# Altium schematic sheet MCU.SchDoc
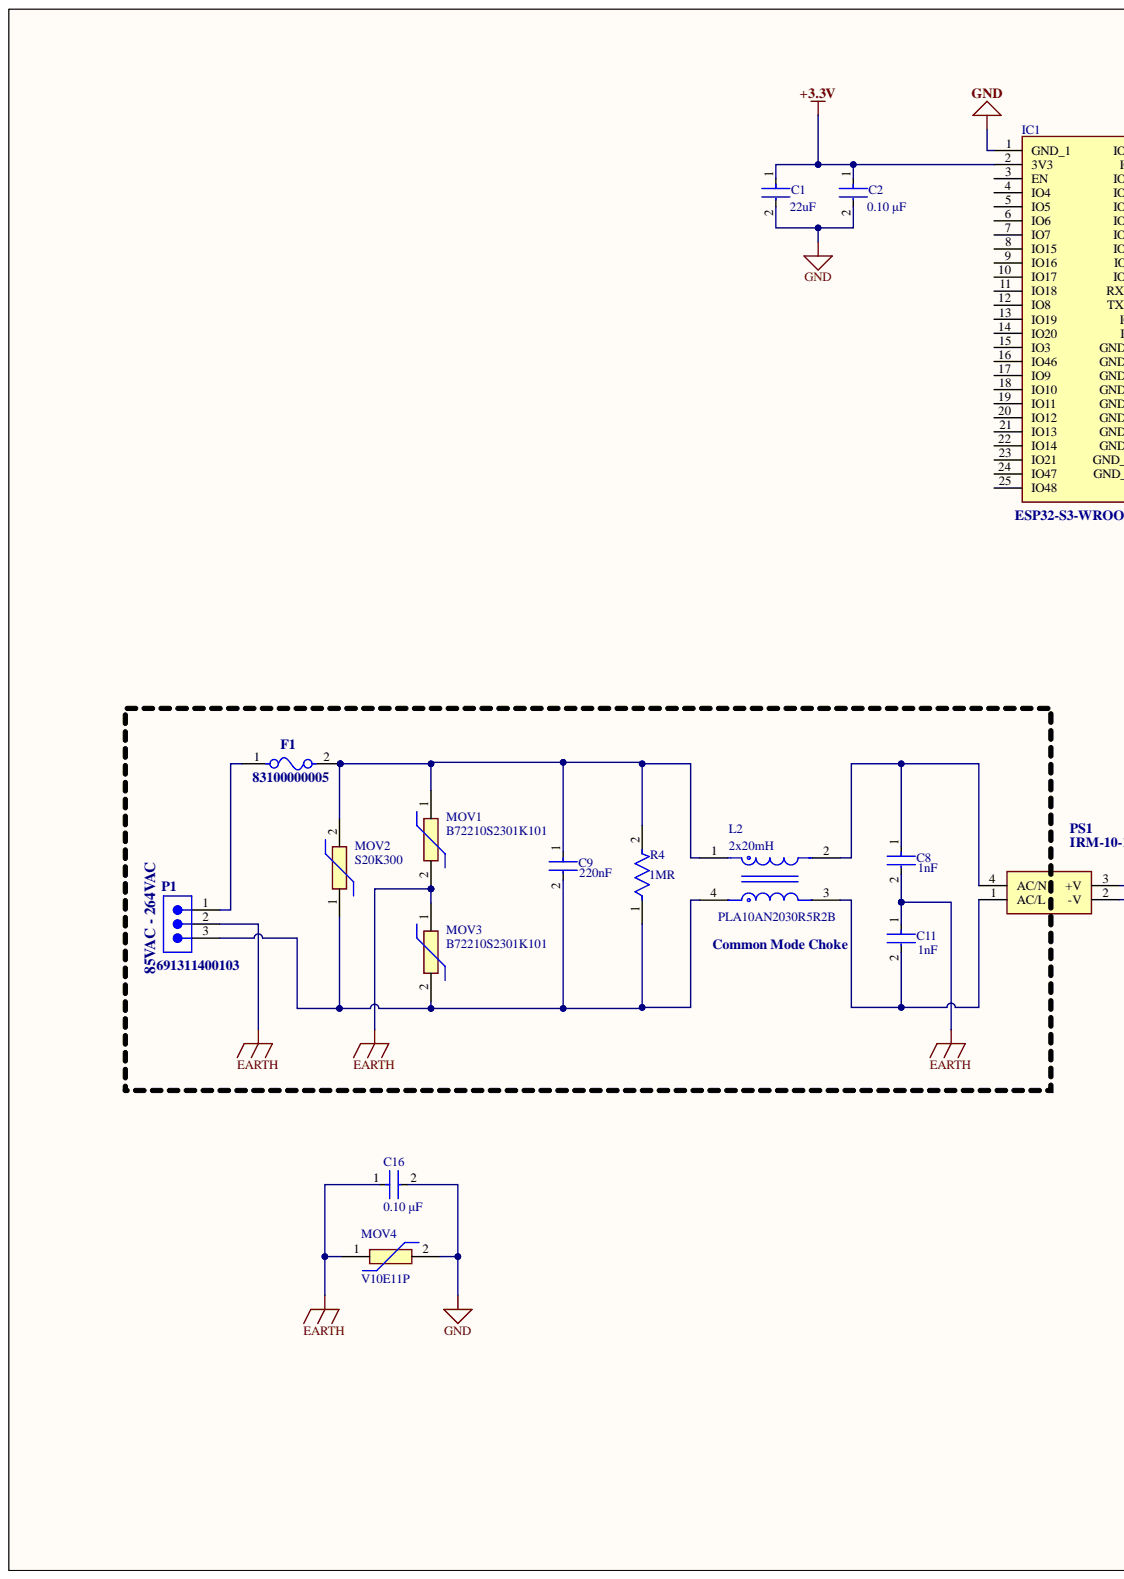
[diagram p0: MCU.SchDoc, left]
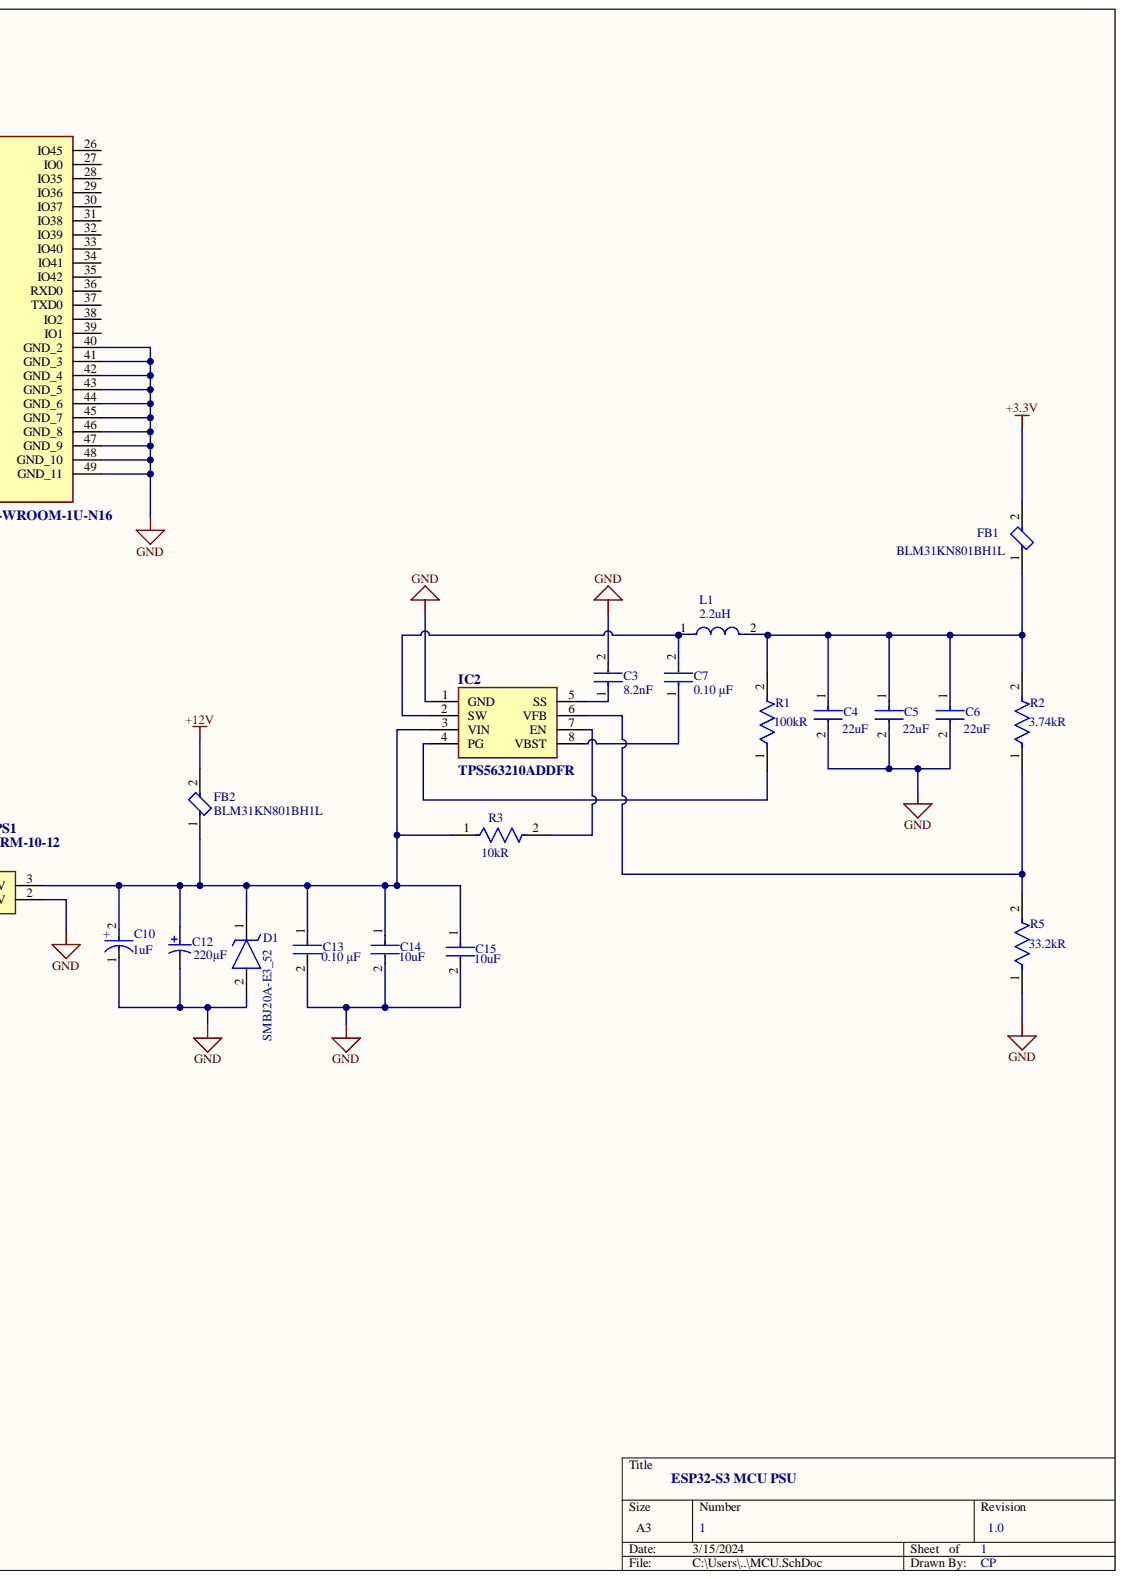
[diagram p1: MCU.SchDoc, right]

## Parts
C1  Capacitance: 22uF  [FP-C4532-250-0_4-IPC_B]  (hidden comment: C4532X7R1E226M250KC)
C2  value 0.10 μF  Capacitance: 0.10 μF  [FP-C1206C-EB-MFG]  (hidden comment: C1206C104K2RACTU)
C3  Capacitance: 8.2nF  [FP-CGA5L-0_3-0_1-IPC_A]  (hidden comment: CGA5H4C0G2W822J115AA)
C4  Capacitance: 22uF  [FP-C4532-250-0_4-IPC_B]  (hidden comment: C4532X7R1E226M250KC)
C5  Capacitance: 22uF  [FP-C4532-250-0_4-IPC_B]  (hidden comment: C4532X7R1E226M250KC)
C6  Capacitance: 22uF  [FP-C4532-250-0_4-IPC_B]  (hidden comment: C4532X7R1E226M250KC)
C7  value 0.10 μF  Capacitance: 0.10 μF  [FP-C1206C-EB-MFG]  (hidden comment: C1206C104K2RACTU)
C8  Capacitance: 1nF  [FP-VY1102M31Y5UC63V0-MFG]  (hidden comment: VY1102M31Y5UC63V0)
C9  Capacitance: 220nF  [FP-C5750-230-0_4-IPC_C]  (hidden comment: C5750JB2J224K230KA)
C10  Capacitance: 1uF  [FP-T491D476K025AT-MFG]  (hidden comment: T491D476K025AT)
C11  Capacitance: 1nF  [FP-VY1102M31Y5UC63V0-MFG]  (hidden comment: VY1102M31Y5UC63V0)
C12  Capacitance: 220µF  [PNSC-FK-V-H13_V]  (hidden comment: EEVFK1J221Q)
C13  value 0.10 μF  Capacitance: 0.10 μF  [FP-C1206C-EB-MFG]  (hidden comment: C1206C104K2RACTU)
C14  value 10uF  Capacitance: 10uF  [FP-GRM31-0_2-MFG]  (hidden comment: GRM31CR61H106KA12L)
C15  value 10uF  Capacitance: 10uF  [FP-GRM31-0_2-MFG]  (hidden comment: GRM31CR61H106KA12L)
C16  value 0.10 μF  Capacitance: 0.10 μF  [FP-C1206C-EB-MFG]  (hidden comment: C1206C104K2RACTU)
D1  SMBJ20A-E3_52  [DIOM5436X244N]
F1  83100000005  [FP-831-MFG]
FB1  BLM31KN801BH1L  [FP-BLM31-0_2-t1_6-MFG]
FB2  BLM31KN801BH1L  [FP-BLM31-0_2-t1_6-MFG]
IC1  ESP32-S3-WROOM-1U-N16  [ESP32S3WROOM1UN16]
IC2  TPS563210ADDFR  [SOT65P280X110-8N]
L1  Inductance: 2.2uH  [FP-LQM31PN_00-MFG]  (hidden comment: LQM31PN2R2M00L)
L2  PLA10AN2030R5R2B  Parameter 1: 2x20mH  [FP-PLA10A-PLH10A-MFG]
MOV1  B72210S2301K101  [B72210S2301K101]
MOV2  S20K300  [S20K300]
MOV3  B72210S2301K101  [B72210S2301K101]
MOV4  V10E11P  [V10E11P]
P1  691311400103  [691311400103]
PS1  IRM-10-12  [IRM-10]
R1  Resistance: 100kR  [FP-CRCW1206-e3-IPC_C]  (hidden comment: CRCW1206100KJNEB)
R2  Resistance: 3.74kR  [FP-MCA1206-IPC_A]  (hidden comment: MCA1206MD1002BP100)
R3  Resistance: 10kR  [FP-MCA1206-IPC_A]  (hidden comment: MCA1206MD1002BP100)
R4  Resistance: 1MR  [FP-CRCW1206-e3-IPC_C]  (hidden comment: CRCW1206100KJNEB)
R5  Resistance: 33.2kR  [FP-MCA1206-IPC_A]  (hidden comment: MCA1206MD1002BP100)

## Nets
+3.3V: C1.1 C2.1 FB1.2 IC1.2(3V3)
+12V: FB2.2
EARTH: C8.2 C11.1 C16.1 MOV1.2 MOV3.1 MOV4.1 P1.2
GND: C1.2 C2.2 C3.2 C4.2 C5.2 C6.2 C10.1 C12.2 C13.2 C14.2 C15.2 C16.2 D1.2 IC1.1(GND_1) IC1.40(GND_2) IC1.41(GND_3) IC1.42(GND_4) IC1.43(GND_5) IC1.44(GND_6) IC1.45(GND_7) IC1.46(GND_8) IC1.47(GND_9) IC1.48(GND_10) IC1.49(GND_11) IC2.1(GND) MOV4.2 PS1.2(-V) R5.1
NetC3_1: C3.1 IC2.5(SS)
NetC4_1: C4.1 C5.1 C6.1 FB1.1 L1.2 R1.2 R2.2
NetC7_1: C7.1 IC2.8(VBST)
NetC7_2: C7.2 IC2.2(SW) L1.1
NetC8_1: C8.1 L2.2 PS1.4(AC/N)
NetC9_1: C9.1 F1.2 L2.1 MOV1.1 MOV2.2 R4.2
NetC9_2: C9.2 L2.4 MOV2.1 MOV3.2 P1.3 R4.1
NetC10_2: C10.2 C12.1 C13.1 C14.1 C15.1 D1.1 FB2.1 IC2.3(VIN) PS1.3(+V) R3.1
NetC11_2: C11.2 L2.3 PS1.1(AC/L)
NetF1_1: F1.1 P1.1
NetIC2_4: IC2.4(PG) R1.1
NetIC2_6: IC2.6(VFB) R2.1 R5.2
NetIC2_7: IC2.7(EN) R3.2

Not connected: IC1 3(EN) 4(IO4) 5(IO5) 6(IO6) 7(IO7) 8(IO15) 9(IO16) 10(IO17) 11(IO18) 12(IO8) 13(IO19) 14(IO20) 15(IO3) 16(IO46) 17(IO9) 18(IO10) 19(IO11) 20(IO12) 21(IO13) 22(IO14) 23(IO21) 24(IO47) 25(IO48) 26(IO45) 27(IO0) 28(IO35) 29(IO36) 30(IO37) 31(IO38) 32(IO39) 33(IO40) 34(IO41) 35(IO42) 36(RXD0) 37(TXD0) 38(IO2) 39(IO1)

## Library data of the parts
C1 C4-C6 = CMP-08246-003643-1: Multilayer Ceramic Capacitors 22uF ±20% 25V X7R SMD 1812; Case Code (Imperial): 1812; Case Code (Metric): 4532; Case/Package: 1812; Component Kind: Standard; Construction: Flat; Depth: 3.2mm; Dielectric: X7R; Dielectric Material: Ceramic; Height: 2.5mm; Lead Free: Lead Free; Length: 4.5mm; Library Name: Altium Content Vault; Max Operating Temperature: 125°C; Min Operating Temperature: -55°C; Mount: Surface Mount; Number of Pins: 2; Package Quantity: 1; Packaging: Tape and Reel; Radiation Hardening: No; REACH SVHC: No SVHC; Resistor Type: Ceramic; RoHS Compliant: Yes; Series: C; Termination: SMD/SMT; Thickness: 0.11inch; Tolerance: 20%; Voltage: 25V; Voltage Rating: 25V; Voltage Rating (DC): 25V; Weight: 0.000952oz; Width: 0.126inch
C2 C7 C13 C16 = CMP-1037-04222-2: CAP CER 0.1UF 200V 10% X7R 1206; Manufacturer: KEMET; Automotive Grade: Grade 0; Material: Ceramic; Temperature Coefficient: 15%; Tolerance: 0.1; Automotive: Yes; Category: Cap; Component Kind: Standard; ComponentLink2Description: Datasheet; ComponentLink2URL: https://content.kemet.com/datasheets/KEM_C1007_X8R_ULTRA_150C_SMD.pdf; Device Class L1: Passive Components; Device Class L2: Capacitors; Device Class L3: Ceramic Capacitors; Digikey Description: CAP CER 0.1UF 200V 10% X7R 1206; Height: 0.88mm; Ipc Land Pattern Name: CAPC320160X78; Lead Free: Yes; Library Name: Altium Content Vault; Manufacturer Part Number: C1206C104K2RACTU; Mouser Description: Multilayer Ceramic Capacitors MLCC - SMD/SMT 200volts 0.1uF X7R 10%; Octopart: https://octopart.com/c1206c104k2ractu-kemet-9138344; Package: C1206C-EB; RoHS: TRUE; Temperature: -55°C to +150°C; Temperature Characteristic: X7R; Temperature Range High: +150°C; Temperature Range Low: -55°C; Voltage Rating: 200 V
C3 = CMP-08246-000279-1: Cap Ceramic 8.2nF 450V C0G 5% Pad SMD 1206 +125°C Automotive T/R; Case Code (Imperial): 1206; Case Code (Metric): 3216; Case/Package: 1206; Component Kind: Standard; Composition: Ceramic; Dielectric: C0G; Height: 1.15mm; Length: 0.126inch; Library Name: Altium Content Vault; Max Operating Temperature: 125°C; Min Operating Temperature: -55°C; Mount: Surface Mount; Package Quantity: 2000; Packaging: Tape and Reel; Ratings: AEC-Q200; Termination: SMD/SMT; Thickness: 0.051inch; Tolerance: 5%; Voltage Rating: 450V; Voltage Rating (DC): 450V; Width: 0.063inch
C8 C11 = CMP-00030-59531954-1: VY1102M31Y5UC63V0 1nF 500(Y1)V ac; 760(X1)V ac Ceramic Single Layer Cap; Y5U Dielectric VY1 Series; Dissipation Factor: 2.5%; Lead/Base Style: Kinked; Ratings: X1, Y1; Tolerance: 20%; Case/Package: Radial; Component Kind: Standard; Depth: 5mm; Diameter: 8mm; Dielectric: Y5U; Dielectric Material: Ceramic; Height - Seated (Max): 11.9888mm; Lead Pitch: 10.0076mm; Library Name: Altium Content Vault; Max Operating Temperature: 125°C; Min Operating Temperature: -40°C; Mount: Through Hole; NexarPartId: 59531954; Packaging: Bulk; REACH SVHC: Unknown; RoHS: Compliant; Schedule B: 8532230060, 8532230060; Termination: Radial
C9 = CMP-08246-006666-1: Multilayer Ceramic Capacitors 220nF ±10% 630V JB SMD 2220; Case Code (Imperial): 2220; Case Code (Metric): 5750; Case/Package: 2220; Component Kind: Standard; Height: 2.3mm; Length: 0.224inch; Library Name: Altium Content Vault; Max Operating Temperature: 85°C; Min Operating Temperature: -25°C; Mount: Surface Mount; Package Quantity: 500; Packaging: Tape and Reel; Resistor Type: Ceramic; RoHS Compliant: Yes; Series: C; Termination: SMD/SMT; Thickness: 0.098inch; Tolerance: 10%; Voltage Rating: 630V; Voltage Rating (DC): 630V; Width: 5mm
C10 = CMP-03017-000020-1: CAP TANT 1UF 10% 25V 2917; Dielectric Material: Tantalum; ESR (Equivalent Series Resistance): 700mR; Leakage Current: 11.8uA; Life (Hours): 2000Hour; Polarity: Polar; Ripple Current: 463mA; Case Code (Imperial): 2917; Case Code (Metric): 7343; Case/Package: 2917; Component Kind: Standard; Depth: 4.3mm; Dissipation Factor: 10%; Failure Rate: 0.001%; Features: General Purpose; Height: 2.8mm; Height - Seated (Max): 0.122inch; Lead Free: Lead Free; Length: 7.3mm; Library Name: Altium Content Vault; Max Operating Temperature: 125°C; Min Operating Temperature: -55°C; Mount: Surface Mount; Number of Pins: 2; Package Quantity: 1; Packaging: Tape and Reel; Radiation Hardening: No; REACH SVHC: No SVHC; RoHS Compliant: Yes; Termination: SMD/SMT; Tolerance: 10%; Voltage: 25V; Voltage Rating: 25V; Voltage Rating (DC): 25V; Weight: 0.023634oz; Width: 4.3mm
C12 = CMP-2000-06222-1: Aluminum Electrolytic Capacitor, 220 uF, +/- 20%, 63 V, 2-Pin SMD (Size Code H13), -55 to 105 degC, RoHS, Tape and Reel; Manufacturer: Panasonic; AE Capacitor Case: RadialCan-SMD; External Length / Height: 13.5mm; Impedance: 0.16ohm; Life Time @ Temperature: 2000hours@105°C; Operating life Time: 2000h; Power Factor: 0.08; Power Factor Frequency: 120Hz; Product Range: PANASONIC-?????FK; Ripple Current AC: 800mA; Ripple Current Frequency: 100kHz; Ripple Current Temperature: 105°C; SVHC: NoSVHC(16-Dec-2013); Voltage Rating: 63V; Capacitance Tolerance: ±20%; Capacitance Tolerance ±: ±20%; Capacitor Case Style: SMD; Capacitor Terminals: SMD; Component Kind: Standard; Datasheet URL: https://industrial.panasonic.com/cdbs/www-data/pdf/RDE0000/ABA0000C1181.pdf; Datasheet Version: 03/2016; Diameter: 12.5mm; Height: 13.5mm; Library Name: Altium Content Vault; Lifetime @ Temperature: 2000hours@105°C; Manufacturer URL: http://www.panasonic.com/; Mounting Technology: SurfaceMount; Operating Lifetime: 2000h; Operating Temperature Max: 105°C; Operating Temperature Min: -55°C; Operating Temperature Range: -55°Cto+105°C; Package / Case: H13; Package Description: 2-Pin Electrolytic Aluminum Capacitor, Body 13.5 x 13.5 mm; Package Reference: FK-V-H13; Package URL: http://industrial.panasonic.com/cdbs/www-data/pdf/RDE0000/DME0000COL48.pdf; Packaging: CutTape; Series: FK; Tolerance +: 20%; Tolerance -: 20%; Voltage Rating V DC: 63V
C14 C15 = CMP-2000-05256-2: CAP CER 10UF 50V X5R 1206; Manufacturer: Murata Electronics North America; Material: Ceramic; Temperature Coefficient: 15%; Tolerance: 10%; Automotive: No; Category: Cap; Component Kind: Standard; ComponentLink2Description: Datasheet; ComponentLink2URL: https://search.murata.co.jp/Ceramy/image/img/A01X/G101/ENG/GRM31CR61H106KA12-01A.pdf; Device Class L1: Passive Components; Device Class L2: Capacitors; Device Class L3: Ceramic Capacitors; Digikey Description: CAP CER 10UF 50V X5R 1206; Height: 1.8mm; Lead Free: Yes; Library Name: Altium Content Vault; Manufacturer Part Number: GRM31CR61H106KA12L; Mouser Description: Multilayer Ceramic Capacitors MLCC - SMD/SMT 1206 10uF 50volt X5R +/-10%; Octopart: https://octopart.com/grm31cr61h106ka12l-murata-18903335; Package: GRM31-0.2; RoHS: TRUE; Temperature: -55°C to +85°C; Temperature Characteristic: X5R; Temperature Range High: +85°C; Temperature Range Low: -55°C; Voltage Rating: 50V
D1 = SMBJ20A-E3_52: TVS Diode (Uni-directional); Manufacturer_Name: Vishay; Manufacturer_Part_Number: SMBJ20A-E3/52; Arrow Part Number: SMBJ20A-E3/52; Arrow Price/Stock: https://www.arrow.com/en/products/smbj20a-e352/vishay?region=nac; Component Kind: Standard; Datasheet Link: http://www.vishay.com/docs/88392/smbj.pdf; Height: 2.44mm; Mouser Part Number: 625-SMBJ20A-E3; Mouser Price/Stock: https://www.mouser.co.uk/ProductDetail/Vishay-General-Semiconductor/SMBJ20A-E3-52?qs=%252BKsK5HlK7GGHijeGSDEfxQ%3D%3D
F1 = CMP-08604-000006-1: FUSE HOLDER CART 250V 6.3A PCB; Manufacturer: Littelfuse Inc; Conn Orientation: Vertical; Fuse Current: 16A; Number Of Fuses: 1; Standoff Height: 0.6mm; Automotive: No; Category: Fuse; Component Kind: Standard; ComponentLink2Description: Datasheet; ComponentLink2URL: https://www.littelfuse.com/~/media/electronics/datasheets/fuse_holders/littelfuse_fuse_holder_830_831_834_datasheet.pdf.pdf; Device Class L1: Circuit Protection; Device Class L2: Fuse Holders; Device Class L3: unset; Digikey Description: FUSE HOLDER CART 250V 6.3A PCB; Height: 32.8mm; Lead Free: Yes; Library Name: Altium Content Vault; Manufacturer Part Number: 83100000005; Mouser Description: Fuse Holder 5x20MM PCB MNT VERT BODY ONLY 4P; Octopart: https://octopart.com/83100000005-littelfuse-743619; Package: 831; RoHS: TRUE; Temperature: -25°C to +70°C; Temperature Range High: +70°C; Temperature Range Low: -25°C; Voltage Rating Ac: 250V; Voltage Rating Dc: 250V
FB1 FB2 = CMP-06046-002315-1: Chip Ferrite Bead, 1206, 800Ω @ 100MHz, 0.05Ω, 25%, 1.7A; DC Resistance (DCR): 50mR; Number of Lines: 1; Case Code (Imperial): 1206; Case Code (Metric): 3216; Case/Package: 1206; Component Kind: Standard; Library Name: Altium Content Vault; Max Current Rating: 1.7A; Max Operating Temperature: 150°C; Min Operating Temperature: -55°C; Mount: Surface Mount; Packaging: Cut Tape; Ratings: AEC-Q200; RoHS Compliant: Yes
IC1 = ESP32-S3-WROOM-1U-N16: Integrated Circuit; Manufacturer_Name: Espressif Systems; Component Kind: Standard; Datasheet Link: https://www.espressif.com/sites/default/files/documentation/esp32-s3-wroom-1_wroom-1u_datasheet_en.pdf; Height: 3.35mm; Library Name: SamacSys.SchLib; Manufacturer_Part_Number: ESP32-S3-WROOM-1U-N16; Mouser Part Number: 356-ESP32S3WROM1UN16; Mouser Price/Stock: https://www.mouser.co.uk/ProductDetail/Espressif-Systems/ESP32-S3-WROOM-1U-N16?qs=Li%252BoUPsLEntAI1ynUyotnA%3D%3D
IC2 = TPS563210ADDFR: Integrated Circuit; Manufacturer_Name: Texas Instruments; Arrow Part Number: TPS563210ADDFR; Arrow Price/Stock: https://www.arrow.com/en/products/tps563210addfr/texas-instruments; Component Kind: Standard; Datasheet Link: http://www.ti.com/lit/gpn/TPS563210A; Height: 1.1mm; Library Name: SamacSys.SchLib; Manufacturer_Part_Number: TPS563210ADDFR; Mouser Part Number: 595-TPS563210ADDFR; Mouser Price/Stock: https://www.mouser.co.uk/ProductDetail/Texas-Instruments/TPS563210ADDFR?qs=0C8XhJW8e4r80ZvhGvpDUQ%3D%3D
L1 = CMP-06042-016285-1: FIXED IND 2.2UH 900MA 190 MOHM; Core Material: Ferrite; DC Resistance (DCR): 190mR; Inductance Tolerance: 20%; Self Resonant Frequency: 40MHz; Shielding: Shielded; Test Frequency: 1MHz; Case Code (Imperial): 1206; Case Code (Metric): 3216; Case/Package: 1206; Component Kind: Standard; Current Rating: 900mA; DC Current: 900mA; Depth: 1.8mm; Height: 0.85mm; Lead Free: Lead Free; Length: 3.2mm; Library Name: Altium Content Vault; Max DC Current: 900mA; Max Operating Temperature: 125°C; Military Standard: Not; Min Operating Temperature: -40°C; Mount: Surface Mount; Package Quantity: 3000; Packaging: Tape and Reel; Radiation Hardening: No; Rated Current-Max: 900mA; REACH SVHC: No SVHC; RoHS Compliant: Yes; Series: LQM31P; Termination: SMD/SMT; Tolerance: 20%; Width: 1.6mm
L2 = CMP-06045-000412-1: Common Mode Choke Dual 20mH 0.5A 1.8Ω DCR Thru-Hole Bulk; Current: 500mA; Current Rating: 500uA; DC Resistance (DCR): 100mR; Lead Diameter: 0.9mm; Lead/Base Style: Pin; Number of Lines: 2; Shielding: Unshielded; Voltage Rating: 300V; Component Kind: Standard; DC Current: 500mA; Depth: 16mm; Height: 17.5mm; Inductance: 20mH; Insulation Resistance: 100MR; Lead Free: Lead Free; Lead Pitch: 13mm; Length: 18mm; Library Name: Altium Content Vault; Max DC Current: 500mA; Max Operating Temperature: 120°C; Military Standard: Not; Min Operating Temperature: -25°C; Mount: Through Hole; Number of Pins: 4; Package Quantity: 1260; Packaging: Bulk; Radiation Hardening: No; REACH SVHC: No SVHC; RMS Current (Irms): 500mA; Termination: Radial; Voltage Rating (AC): 300V; Voltage Rating (DC): 300V; Width: 0.63inch
MOV1 MOV3 = B72210S2301K101: Varistor; Manufacturer_Name: TDK; Arrow Part Number: B72210S2301K101; Arrow Price/Stock: https://www.arrow.com/en/products/b72210s2301k101/tdk?region=nac; Component Kind: Standard; Datasheet Link: https://product.tdk.com/system/files/dam/doc/product/protection/voltage/lead-disk-varistor/data_sheet/70/db/var/siov_leaded_advanced.pdf; Height: 14.5mm; Manufacturer_Part_Number: B72210S2301K101; Mouser Part Number: 871-B72210S2301K101; Mouser Price/Stock: https://www.mouser.co.uk/ProductDetail/EPCOS-TDK/B72210S2301K101?qs=xN3cbABbNRaO1wG4NhAEjg%3D%3D
MOV2 = S20K300: Varistor; Manufacturer_Name: TDK; Component Kind: Standard; Datasheet Link: https://www.tdk-electronics.tdk.com/inf/70/db/var/SIOV_Leaded_StandarD.pdf; Height: 25.5mm; Manufacturer_Part_Number: S20K300
MOV4 = V10E11P: Varistor; Manufacturer_Name: LITTELFUSE; Component Kind: Standard; Datasheet Link: https://www.littelfuse.com/~/media/electronics/datasheets/varistors/littelfuse_varistor_lv_ultramov_datasheet.pdf.pdf; Height: 16mm; Manufacturer_Part_Number: V10E11P
P1 = CMP-1502-02409-2: NexarPartId: 18818299; Current: 20A; Gender: Male; Number of Contacts: 3; Number of Levels: 1; Pitch: 7.62mm; Voltage: 300V; Color: Green; Component Kind: Standard; Contact Material: Brass; Current Rating: 20A; Height: 12.15mm; Lead Pitch: 7.62mm; Length: 8.5mm; Library Name: Altium Content Vault; Max Operating Temperature: 105°C; Min Operating Temperature: -40°C; Mount: Through Hole; Number of Pins: 3; Number of Positions Per Level: 3; Number of Rows: 1; Orientation: Vertical; Packaging: Box; REACH SVHC: Unknown; RoHS: Compliant; Termination: Solder; Voltage Rating: 300V; Width: 22.86mm
PS1 = IRM-10-12: Power Supply; Manufacturer_Name: Mean Well; Arrow Part Number: IRM-10-12; Arrow Price/Stock: https://www.arrow.com/en/products/irm-10-12/mean-well-enterprises?region=europe; Component Kind: Standard; Datasheet Link: https://www.meanwellusa.com/webapp/product/search.aspx?prod=IRM-10; Manufacturer_Part_Number: IRM-10-12; Mouser Part Number: 709-IRM10-12; Mouser Price/Stock: https://www.mouser.co.uk/ProductDetail/MEAN-WELL/IRM-10-12?qs=WkdRfq4wf1Mps3oD7xn11w%3D%3D
R1 = CMP-02407-009021-1: RES Thick Film, 100kΩ, 5%, 0.25W, 200ppm/°C, 1206; Max Power Dissipation: 250mW; Voltage Rating: 200V; Case Code (Imperial): 1206; Case Code (Metric): 3216; Case/Package: 1206; Component Kind: Standard; Composition: Thick Film; Contact Plating: Tin; Features: Automotive AEC-Q200; Height: 0.024inch; Lead Free: Lead Free; Length: 0.126inch; Library Name: Altium Content Vault; Max Operating Temperature: 155°C; Min Operating Temperature: -55°C; Number of Terminations: 2; Package Quantity: 10000; Packaging: Tape and Reel; Power Rating: 250mW; Radiation Hardening: No; Temperature Coefficient: 200ppm/°C; Tolerance: 5%; Weight: 0.000353oz; Width: 0.063inch
R2 = CMP-02407-000300-1: Res MC AT Precision Thin Film 1206 3.74kΩ 0.1% 0.4W ±25ppm/°C Molded Paper T/R; Max Power Dissipation: 400mW; Voltage Rating: 200V; Case Code (Imperial): 1206; Case Code (Metric): 3216; Case/Package: 1206; Component Kind: Standard; Composition: Thin Film; Features: Automotive AEC-Q200; Height: 0.026inch; Length: 0.126inch; Library Name: Altium Content Vault; Max Operating Temperature: 155°C; Min Operating Temperature: -55°C; Number of Terminations: 2; Package Quantity: 1000; Packaging: Tape and Reel; Power Rating: 400mW; Temperature Coefficient: 25ppm/°C; Termination: SMD/SMT; Tolerance: 0.1%; Weight: 0.000353oz; Width: 0.063inch
R3 = CMP-02407-000300-1: Res MC AT Precision Thin Film 1206 10kΩ 0.1% 0.4W ±25ppm/°C Molded Paper T/R; Max Power Dissipation: 400mW; Voltage Rating: 200V; Case Code (Imperial): 1206; Case Code (Metric): 3216; Case/Package: 1206; Component Kind: Standard; Composition: Thin Film; Features: Automotive AEC-Q200; Height: 0.026inch; Length: 0.126inch; Library Name: Altium Content Vault; Max Operating Temperature: 155°C; Min Operating Temperature: -55°C; Number of Terminations: 2; Package Quantity: 1000; Packaging: Tape and Reel; Power Rating: 400mW; Temperature Coefficient: 25ppm/°C; Termination: SMD/SMT; Tolerance: 0.1%; Weight: 0.000353oz; Width: 0.063inch
R4 = CMP-02407-009021-1: RES Thick Film, 1M, 5%, 0.25W, 200ppm/°C, 1206; Max Power Dissipation: 250mW; Voltage Rating: 200V; Case Code (Imperial): 1206; Case Code (Metric): 3216; Case/Package: 1206; Component Kind: Standard; Composition: Thick Film; Contact Plating: Tin; Features: Automotive AEC-Q200; Height: 0.024inch; Lead Free: Lead Free; Length: 0.126inch; Library Name: Altium Content Vault; Max Operating Temperature: 155°C; Min Operating Temperature: -55°C; Number of Terminations: 2; Package Quantity: 10000; Packaging: Tape and Reel; Power Rating: 250mW; Radiation Hardening: No; Temperature Coefficient: 200ppm/°C; Tolerance: 5%; Weight: 0.000353oz; Width: 0.063inch
R5 = CMP-02407-000300-1: Res MC AT Precision Thin Film 1206 33.2kΩ 0.1% 0.4W ±25ppm/°C Molded Paper T/R; Max Power Dissipation: 400mW; Voltage Rating: 200V; Case Code (Imperial): 1206; Case Code (Metric): 3216; Case/Package: 1206; Component Kind: Standard; Composition: Thin Film; Features: Automotive AEC-Q200; Height: 0.026inch; Length: 0.126inch; Library Name: Altium Content Vault; Max Operating Temperature: 155°C; Min Operating Temperature: -55°C; Number of Terminations: 2; Package Quantity: 1000; Packaging: Tape and Reel; Power Rating: 400mW; Temperature Coefficient: 25ppm/°C; Termination: SMD/SMT; Tolerance: 0.1%; Weight: 0.000353oz; Width: 0.063inch
